# Altium schematic sheet Output_Connectors.SchDoc
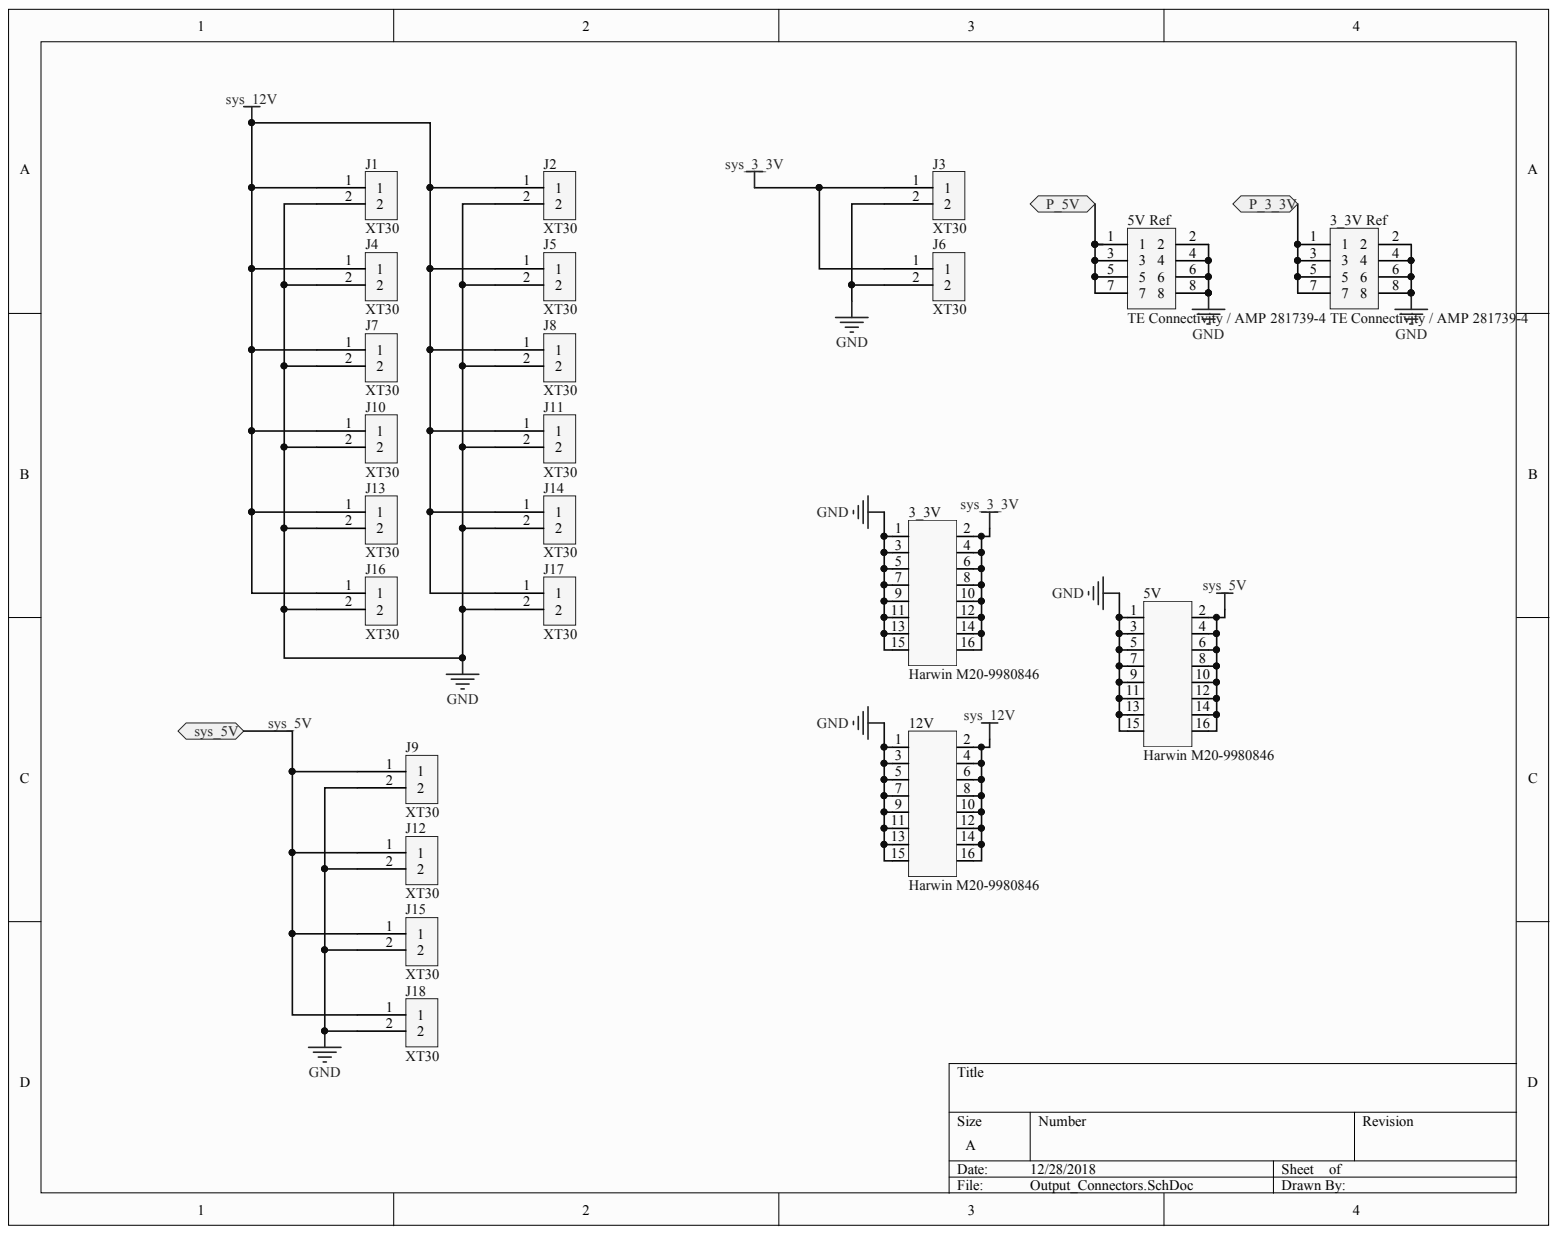
[diagram p0: Output_Connectors.SchDoc]

## Parts
3_3V  Harwin M20-9980846  [2p54mm_8x2]
3_3V_Ref  TE Connectivity / AMP 281739-4  Manufacturer Part Number: 281739-4  [PCB-wntpwawfq0wo0xoaeo5u-1]
5V  Harwin M20-9980846  [2p54mm_8x2]
5V_Ref  TE Connectivity / AMP 281739-4  [PCB-wntpwawfq0wo0xoaeo5u-1]
12V  Harwin M20-9980846  [2p54mm_8x2]
J1  XT30  [PCB-8h6goupi0sbkduz6cbnu-1]
J2  XT30  [PCB-8h6goupi0sbkduz6cbnu-1]
J3  XT30  [PCB-8h6goupi0sbkduz6cbnu-1]
J4  XT30  [PCB-8h6goupi0sbkduz6cbnu-1]
J5  XT30  [PCB-8h6goupi0sbkduz6cbnu-1]
J6  XT30  [PCB-8h6goupi0sbkduz6cbnu-1]
J7  XT30  [PCB-8h6goupi0sbkduz6cbnu-1]
J8  XT30  [PCB-8h6goupi0sbkduz6cbnu-1]
J9  XT30  [PCB-8h6goupi0sbkduz6cbnu-1]
J10  XT30  [PCB-8h6goupi0sbkduz6cbnu-1]
J11  XT30  [PCB-8h6goupi0sbkduz6cbnu-1]
J12  XT30  [PCB-8h6goupi0sbkduz6cbnu-1]
J13  XT30  [PCB-8h6goupi0sbkduz6cbnu-1]
J14  XT30  [PCB-8h6goupi0sbkduz6cbnu-1]
J15  XT30  [PCB-8h6goupi0sbkduz6cbnu-1]
J16  XT30  [PCB-8h6goupi0sbkduz6cbnu-1]
J17  XT30  [PCB-8h6goupi0sbkduz6cbnu-1]
J18  XT30  [PCB-8h6goupi0sbkduz6cbnu-1]

## Ports
P_3_3V, P_5V, SYS_5V

## Nets
GND: 3_3V.1 3_3V.3 3_3V.5 3_3V.7 3_3V.9 3_3V.11 3_3V.13 3_3V.15 3_3V_Ref.2 3_3V_Ref.4 3_3V_Ref.6 3_3V_Ref.8 5V.1 5V.3 5V.5 5V.7 5V.9 5V.11 5V.13 5V.15 5V_Ref.2 5V_Ref.4 5V_Ref.6 5V_Ref.8 12V.1 12V.3 12V.5 12V.7 12V.9 12V.11 12V.13 12V.15 J1.2 J2.2 J3.2 J4.2 J5.2 J6.2 J7.2 J8.2 J9.2 J10.2 J11.2 J12.2 J13.2 J14.2 J15.2 J16.2 J17.2 J18.2
SYS_3_3V: 3_3V.2 3_3V.4 3_3V.6 3_3V.8 3_3V.10 3_3V.12 3_3V.14 3_3V.16 J3.1 J6.1
SYS_12V: 12V.2 12V.4 12V.6 12V.8 12V.10 12V.12 12V.14 12V.16 J1.1 J2.1 J4.1 J5.1 J7.1 J8.1 J10.1 J11.1 J13.1 J14.1 J16.1 J17.1
P_3_3V: 3_3V_Ref.1 3_3V_Ref.3 3_3V_Ref.5 3_3V_Ref.7 ; port P_3_3V
P_5V: 5V_Ref.1 5V_Ref.3 5V_Ref.5 5V_Ref.7 ; port P_5V
SYS_5V: 5V.2 5V.4 5V.6 5V.8 5V.10 5V.12 5V.14 5V.16 J9.1 J12.1 J15.1 J18.1 ; port SYS_5V

## Library data of the parts
3_3V 5V 12V = CMP-c8d6018fe0a31711-1: 2.54mm (0.1") Pitch DIL Vertical PC Tail Pin Header, 6.1mm mating pin height, tin, 8+8 contacts; Insulation Resistance: 10.0 GΩ; Component Kind: Standard; Library Name: CommunityVault; OctopartID: c8d6018fe0a31711; Octopart URL: http://octopart.com/m20-9980846-harwin-5442835
3_3V_Ref 5V_Ref = CMP-f3373a22ee902cf0-3: Conn Shrouded Header HDR 8 POS 2.54mm Solder ST Thru-Hole Loose Piece; Contact Resistance: 30.0 mΩ; Current Rating: 3.00 A; ELV Compliant: Compliant; Gender: Male; Lead-Free Status: Lead Free; Number of Contacts: 8; Number of Mating Cycles: 30; Color: Blue; Component Kind: Standard; Contact Material: Phosphor Bronze; Contact Plating: Tin, Tin over Nickel; Housing Color: Blue; Housing Material: Thermoplastic; Library Name: CommunityVault; Mounting Style: Through Hole; Number of Positions: 8; OctopartID: cb9901de661d145e; Octopart URL: https://octopart.com/281739-4-te+connectivity-55412962; Packaging: Box; REACH SVHC Compliance: No SVHC
  5V_Ref: Manufacturer Part Number: 281739-4
J1-J18 = CMP-nlx8yci0umf6n9sk0ty3-5: Very similar to the XT60 models, but smaller and made for 30A; Component Kind: Standard; CustomComponentID: nlx8yci0umf6n9sk0ty3; Homepage URL: https://www.amazon.com/ProgressiveRC-AC-XT30-XT30-Connectors-pairs/dp/B011WDZGM0; Library Name: FavoritesLibrary
